# Altium schematic sheet SensWear_Touch.SchDoc
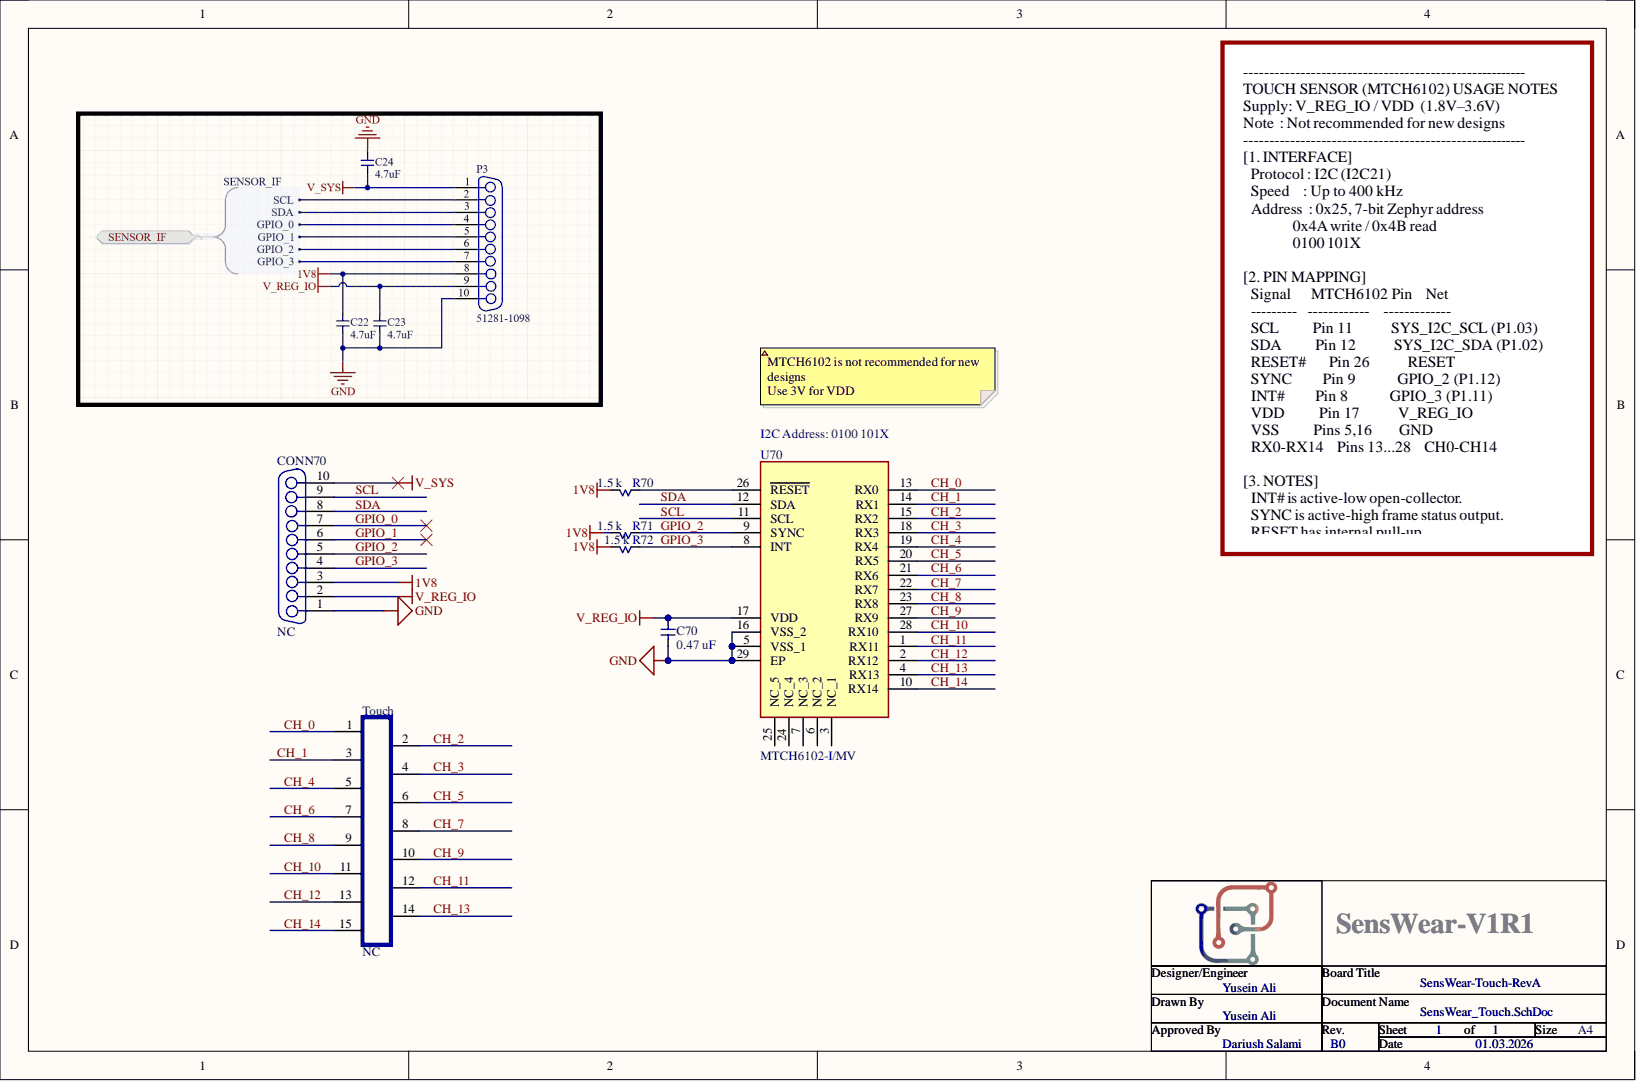
[diagram p0: SensWear_Touch.SchDoc]

## Parts
C70  0.47 uF  [CAPC1005X05N]
CONN70  NC  [51281-1098-PCB]
R70  1.5 k  [RESC1005X04N]
R71  1.5 k  [RESC1005X04N]
R72  1.5 k  [RESC1005X04N]
Touch  NC  [Touch-15Ch-Linear]
U70  MTCH6102-I/MV  [QFN40P400X400X55_HS-29N]

## Nets
1V8: CONN70.3 R70.2 R71.2 R72.2
GND: C70.2 CONN70.1 U70.5(VSS_1) U70.16(VSS_2) U70.29(EP)
V_REG_IO: C70.1 CONN70.2 U70.17(VDD)
V_SYS: CONN70.10
CH_0: Touch.1 U70.13(RX0)
CH_1: Touch.3 U70.14(RX1)
CH_2: Touch.2 U70.15(RX2)
CH_3: Touch.4 U70.18(RX3)
CH_4: Touch.5 U70.19(RX4)
CH_5: Touch.6 U70.20(RX5)
CH_6: Touch.7 U70.21(RX6)
CH_7: Touch.8 U70.22(RX7)
CH_8: Touch.9 U70.23(RX8)
CH_9: Touch.10 U70.27(RX9)
CH_10: Touch.11 U70.28(RX10)
CH_11: Touch.12 U70.1(RX11)
CH_12: Touch.13 U70.2(RX12)
CH_13: Touch.14 U70.4(RX13)
CH_14: Touch.15 U70.10(RX14)
GPIO_0: CONN70.7
GPIO_1: CONN70.6
GPIO_2: CONN70.5 R71.1 U70.9(SYNC)
GPIO_3: CONN70.4 R72.1 U70.8(INT)
SCL: CONN70.9 U70.11(SCL)
SDA: CONN70.8 U70.12(SDA)
NetR70_1: R70.1 U70.26(RESET)

Not connected: U70 3(NC_1) 6(NC_2) 7(NC_3) 24(NC_4) 25(NC_5)

## Library data of the parts
C70 = GRM155R61A474KE15D: CAP CER 0.47UF 10V X5R 0402; Manufacturer: Murata Electronics North America; Capacitance: 0.47µF; Tolerance: ±10%; Applications: General Purpose; Category: Capacitors; Component Kind: Standard; ComponentLink1Description: http://www.murata.com/~/media/webrenewal/support/library/catalog/products/capacitor/mlcc/c02e.pdf; ComponentLink1URL: http://www.murata.com/~/media/webrenewal/support/library/catalog/products/capacitor/mlcc/c02e.pdf; Features: -; Height - Seated (Max): -; Lead Spacing: -; Lead Style: -; Manufacturer Part Number: GRM155R61A474KE15D; Mounting Type: Surface Mount, MLCC; Operating Temperature: -55°C ~ 85°C; Package / Case: 0402 (1005 Metric); Packaging: Digi-Reel®; Part Status: Active; Pricing 1: 1=0.08, 10=0.054, 50=0.029, 100=0.0246, 250=0.02008, 500=0.01718, 1000=0.01339, 5000=0.01116 (GBP); Ratings: -; RoHS: RoHS Compliant; Signal Integrity: Model Name; Size / Dimension: 0.039" L x 0.020" W (1.00mm x 0.50mm); Stock 1: 715573; Supplier 1: Digi-Key; Supplier Part Number 1: 490-3264-6-ND; Temperature Coefficient: X5R; Thickness (Max): 0.022" (0.55mm); Value: 0.47 uF; Voltage - Rated: 10V; Library Name: SensWearComponents.IntLib
CONN70 = 51281-1098-PCB: System: Connector; Component Kind: Standard; Library Name: SensWearComponents.IntLib
R70-R72 = ERJ-2RKF1501X: RES SMD 1.5K OHM 1% 1/10W 0402; Manufacturer: Panasonic Electronic Components; Power (Watts): 0.1W, 1/10W; Temperature Coefficient: ±100ppm/°C; Category: Resistors; Component Kind: Standard; ComponentLink1Description: https://industrial.panasonic.com/cdbs/www-data/pdf/RDA0000/AOA0000C283.pdf; ComponentLink1URL: https://industrial.panasonic.com/cdbs/www-data/pdf/RDA0000/AOA0000C283.pdf; Composition: Thick Film; Failure Rate: -; Features: Automotive AEC-Q200; Height - Seated (Max): 0.016" (0.40mm); Manufacturer Part Number: ERJ-2RKF1501X; Number of Terminations: 2; Operating Temperature: -55°C ~ 155°C; Package / Case: 0402 (1005 Metric); Packaging: Digi-Reel®; Part Status: Active; Pricing 1: 1=0.1, 10=0.025, 25=0.018, 50=0.0136, 100=0.01, 250=0.00764, 500=0.00612, 1000=0.00451, 5000=0.00323 (USD); Resistance (Ohms): 1.5k; RoHS: RoHS Compliant; Signal Integrity: Model Name; Size / Dimension: 0.039" L x 0.020" W (1.00mm x 0.50mm); Stock 1: 1349197; Supplier 1: Digi-Key; Supplier Device Package: 0402; Supplier Part Number 1: P1.50KLDKR-ND; Tolerance: ±1%; Value: 1.5 k; Library Name: SensWearComponents.IntLib
Touch = Touch-15Ch-Linear: Component Kind: Standard; Library Name: SensWearComponents.IntLib
U70 = MTCH6102-I/MV: Capacitive Touch Screen Automotive 28-Pin UQFN EP Tube; Manufacturer: Microchip; Checked: FALSE; Category: Touch Screen Controllers; Component Kind: Standard; ComponentLink1Description: https://datasheet.ciiva.com/29355/filehandler-aspxddocname-en568912-29355091.pdf?src-supplier=Digikey; ComponentLink1URL: https://datasheet.ciiva.com/29355/filehandler-aspxddocname-en568912-29355091.pdf?src-supplier=Digikey; ComponentLink2Description: https://datasheet.ciiva.com/48495/40001750a-48495606.pdf?src-supplier=Avnet; ComponentLink2URL: https://datasheet.ciiva.com/48495/40001750a-48495606.pdf?src-supplier=Avnet; ComponentLink3Description: https://datasheet.ciiva.com/46405/1810724-46405963.pdf?src-supplier=Element14; ComponentLink3URL: https://datasheet.ciiva.com/46405/1810724-46405963.pdf?src-supplier=Element14; Manufacturer Part Number: MTCH6102-I/MV; Pricing: 1=1.95, 25=1.8, 100=1.62 (USD); RoHS: Yes; Stock: 496; Supplier: Digikey; Supplier 1: Digikey; Supplier 2: Mouser; Supplier Part Number: MTCH6102-I/MV-ND; Supplier Part Number 1: MTCH6102-I/MV-ND; Supplier Part Number 2: 579-MTCH6102-I/MV; Library Name: SensWearComponents.IntLib
